# Altium schematic sheet Distance_Sensor.SchDoc
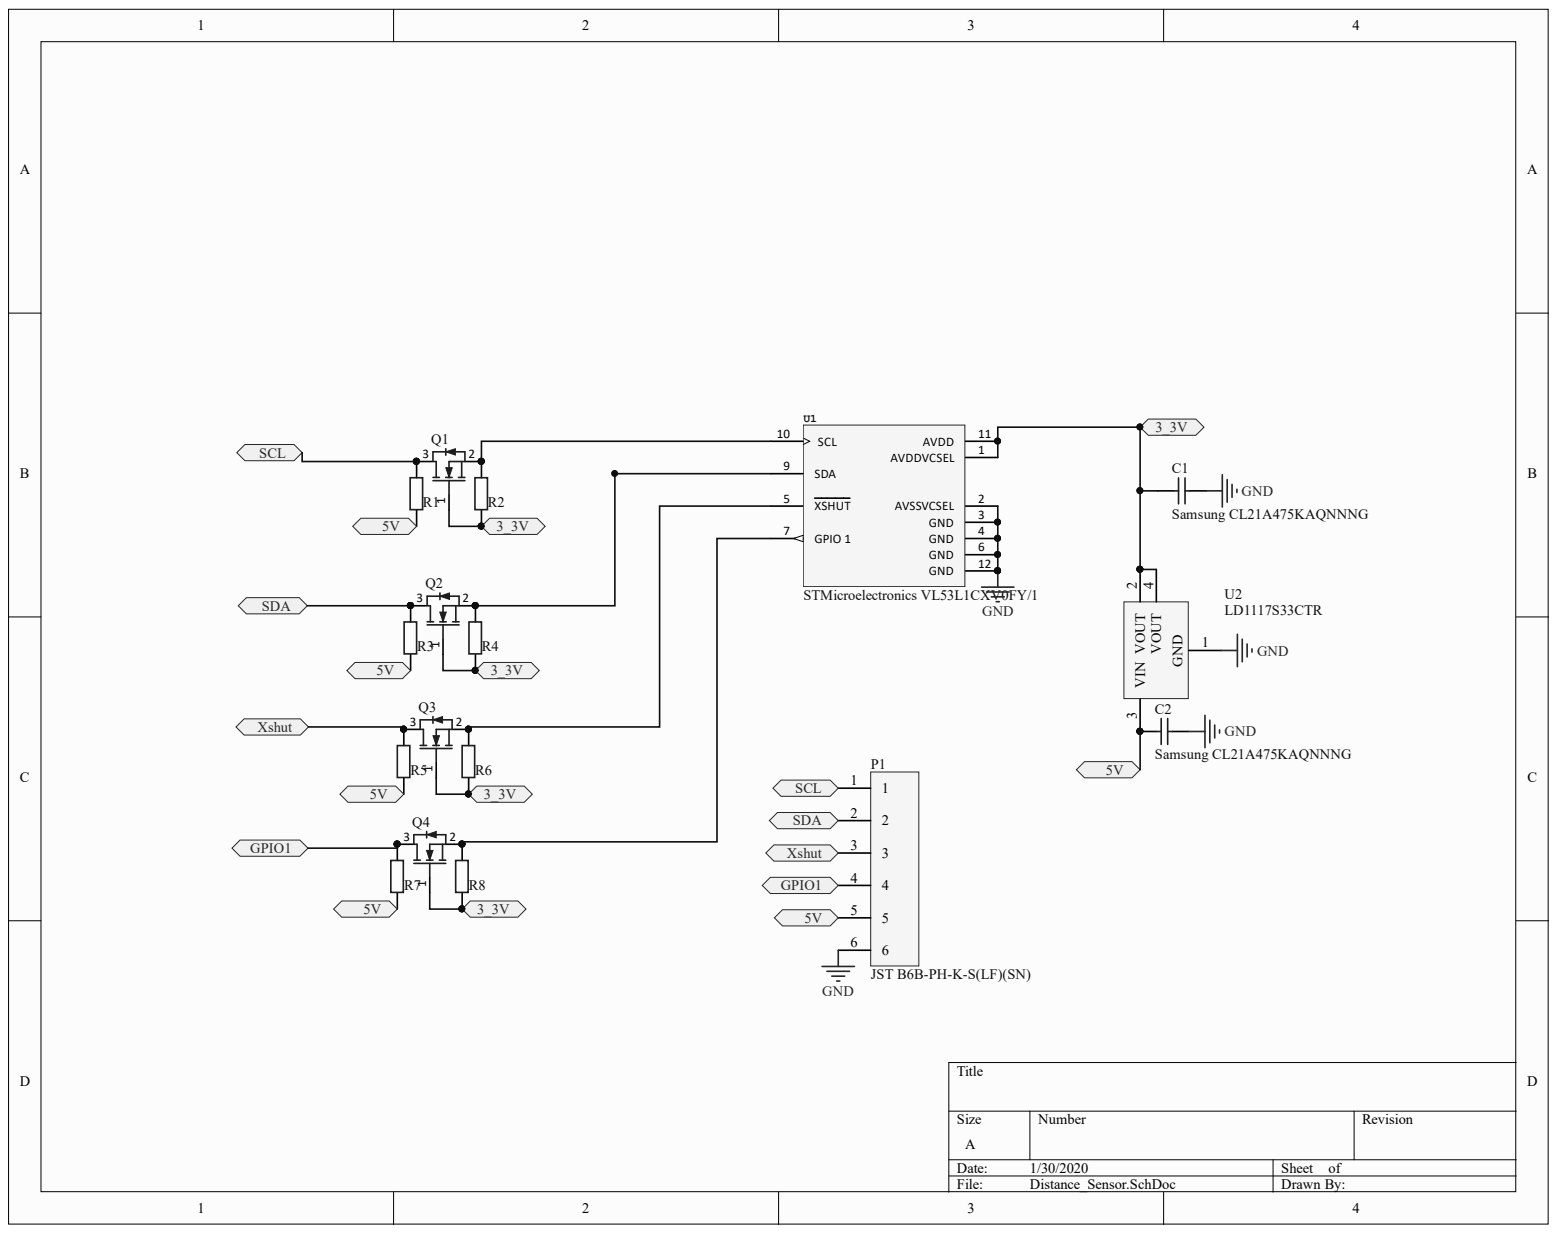
[diagram p0: Distance_Sensor.SchDoc]

## Parts
C1  Samsung CL21A475KAQNNNG  [CL21A475KAQNNNG]
C2  Samsung CL21A475KAQNNNG  [CL21A475KAQNNNG]
P1  JST B6B-PH-K-S(LF)(SN)  Manufacturer Part Number: B6B-PH-K-S(LF)(SN)  [PCB-zgutn5khdenyh1r5q6qg-1]
Q1  Rohm RK7002BMT116  [PCB-lhick5qix2l1q5xknwuk-1]  (value not drawn)
Q2  Rohm RK7002BMT116  [PCB-lhick5qix2l1q5xknwuk-1]  (value not drawn)
Q3  Rohm RK7002BMT116  [PCB-lhick5qix2l1q5xknwuk-1]  (value not drawn)
Q4  Rohm RK7002BMT116  [PCB-lhick5qix2l1q5xknwuk-1]  (value not drawn)
R1  Res2  [PCB-wz0gdj29sqhj23xvqg5w-1]  (value not drawn)
R2  Res2  [PCB-wz0gdj29sqhj23xvqg5w-1]  (value not drawn)
R3  Res2  [PCB-wz0gdj29sqhj23xvqg5w-1]  (value not drawn)
R4  Res2  [PCB-wz0gdj29sqhj23xvqg5w-1]  (value not drawn)
R5  Res2  [PCB-wz0gdj29sqhj23xvqg5w-1]  (value not drawn)
R6  Res2  [PCB-wz0gdj29sqhj23xvqg5w-1]  (value not drawn)
R7  Res2  [PCB-wz0gdj29sqhj23xvqg5w-1]  (value not drawn)
R8  Res2  [PCB-wz0gdj29sqhj23xvqg5w-1]  (value not drawn)
U1  STMicroelectronics VL53L1CXV0FY/1  [PCB-i0ofxptgesccf2psvnpf-1]
U2  LD1117S33CTR  [SOT-223_N]

## Ports
3_3V, 5V, GPIO1, SCL, SDA, XSHUT

## Nets
GND: C1.2 C2.2 P1.6 U1.2(AVSSVCSEL) U1.3(GND) U1.4(GND) U1.6(GND) U1.12(GND) U2.1
XSHUT: P1.3 Q3.3 R5.2 ; port XSHUT
3_3V: C1.1 Q1.1 Q2.1 Q3.1 Q4.1 R2.1 R4.1 R6.1 R8.1 U1.1(AVDDVCSEL) U1.11(AVDD) U2.2(VOUT) U2.4(VOUT) ; port 3_3V
5V: C2.1 P1.5 R1.1 R3.1 R5.1 R7.1 U2.3(VIN) ; port 5V
GPIO1: P1.4 Q4.3 R7.2 ; port GPIO1
SCL: P1.1 Q1.3 R1.2 ; port SCL
SDA: P1.2 Q2.3 R3.2 ; port SDA
NetQ1_2: Q1.2 R2.2 U1.10(SCL)
NetQ2_2: Q2.2 R4.2 U1.9(SDA)
NetQ3_2: Q3.2 R6.2 U1.5(XSHUT)
NetQ4_2: Q4.2 R8.2 U1.7(GPIO_1)

## Library data of the parts
C1 C2 = CMP-cb72032ddab314b5-2: Ceramic capacitor SMD 0805 4.7 µF 25 V 10 % Samsung Electro-Mechanics CL21A475KAQNNNG 3000 pc(s); Capacitance: 4.7uF; Voltage Rating (DC): 25V; Component Kind: Standard; Library Name: CommunityVault
P1 = CMP-97605e697e2e48a7-2: PH Series 6 Position 2 mm Pitch Through Hole Crimp Top Entry Shrouded Header; Contact Resistance: 10.0 mΩ; Current Rating: 2.00 A; Gender: Male; Insulation Resistance: 1.00 MΩ; Lead-Free Status: Lead Free; Number of Contacts: 6; Pin Pitch: 2.00 mm; Voltage Rating (AC): 100 V; Component Kind: Standard; Contact Material: Brass; Contact Plating: Tin; Housing Color: Natural; Housing Material: Nylon; Library Name: CommunityVault; Mounting Style: Through Hole; Number of Positions: 6; Number of Rows: 1; OctopartID: 97605e697e2e48a7; Octopart URL: http://octopart.com/b6b-ph-k-s%28lf%29%28sn%29-jst-248872; Packaging: Bulk; REACH SVHC Compliance: No SVHC; RoHS: Compliant; Size-Height: 6.00 mm; Size-Length: 13.9 mm; Size-Width: 4.50 mm
Q1-Q4 = CMP-c6120e73f56f19d5-4: N-Channel 60 V 0.2 W Surface Mount 2.5 V Drive Silicon Power Mosfet - SOT-23; Component Kind: Standard; Library Name: CommunityVault
R1-R8 = CMP-494d8056daa7968a-25: Res Thick Film 0603 10K Ohm 5% 1/10W ±100ppm/°C Molded SMD SMD Paper T/R; Component Kind: Standard; Library Name: CommunityVault; Octopart URL: https://octopart.com/rc0603jr-0710kl-yageo-1160844; REACH SVHC Compliance: No SVHC
U1 = CMP-646fa835e915bba8-9: VL53L1X Series 3.5 V New Generation Long Distance Ranging Time-of-Flight Sensor; Component Kind: Standard; Library Name: CommunityVault
U2 = CMP-1170392-2: Fixed Low Drop Positive Voltage Regulator, 3.3V, 4-Pin SOT-223, Tape and Reel; Dropout Voltage: 1.1 V; Lead-Free Status: Lead Free; Number of Outputs: 1; Case/Package: SOT-223; Component Kind: Standard; Library Name: CommunityVault; Lifecycle Status: Active; Manufacturer Part Number: LD1117S33CTR; Mounting Style: Surface Mount; Number of Pins: 3; OctopartID: 0754ce562bb7d893; Octopart Url: https://octopart.com/ld1117s33ctr-stmicroelectronics-526725; Output Voltage: 3.3 V; REACH SVHC Compliance: No SVHC; RoHS: Compliant
